# Altium schematic sheet Sheet1.SchDoc
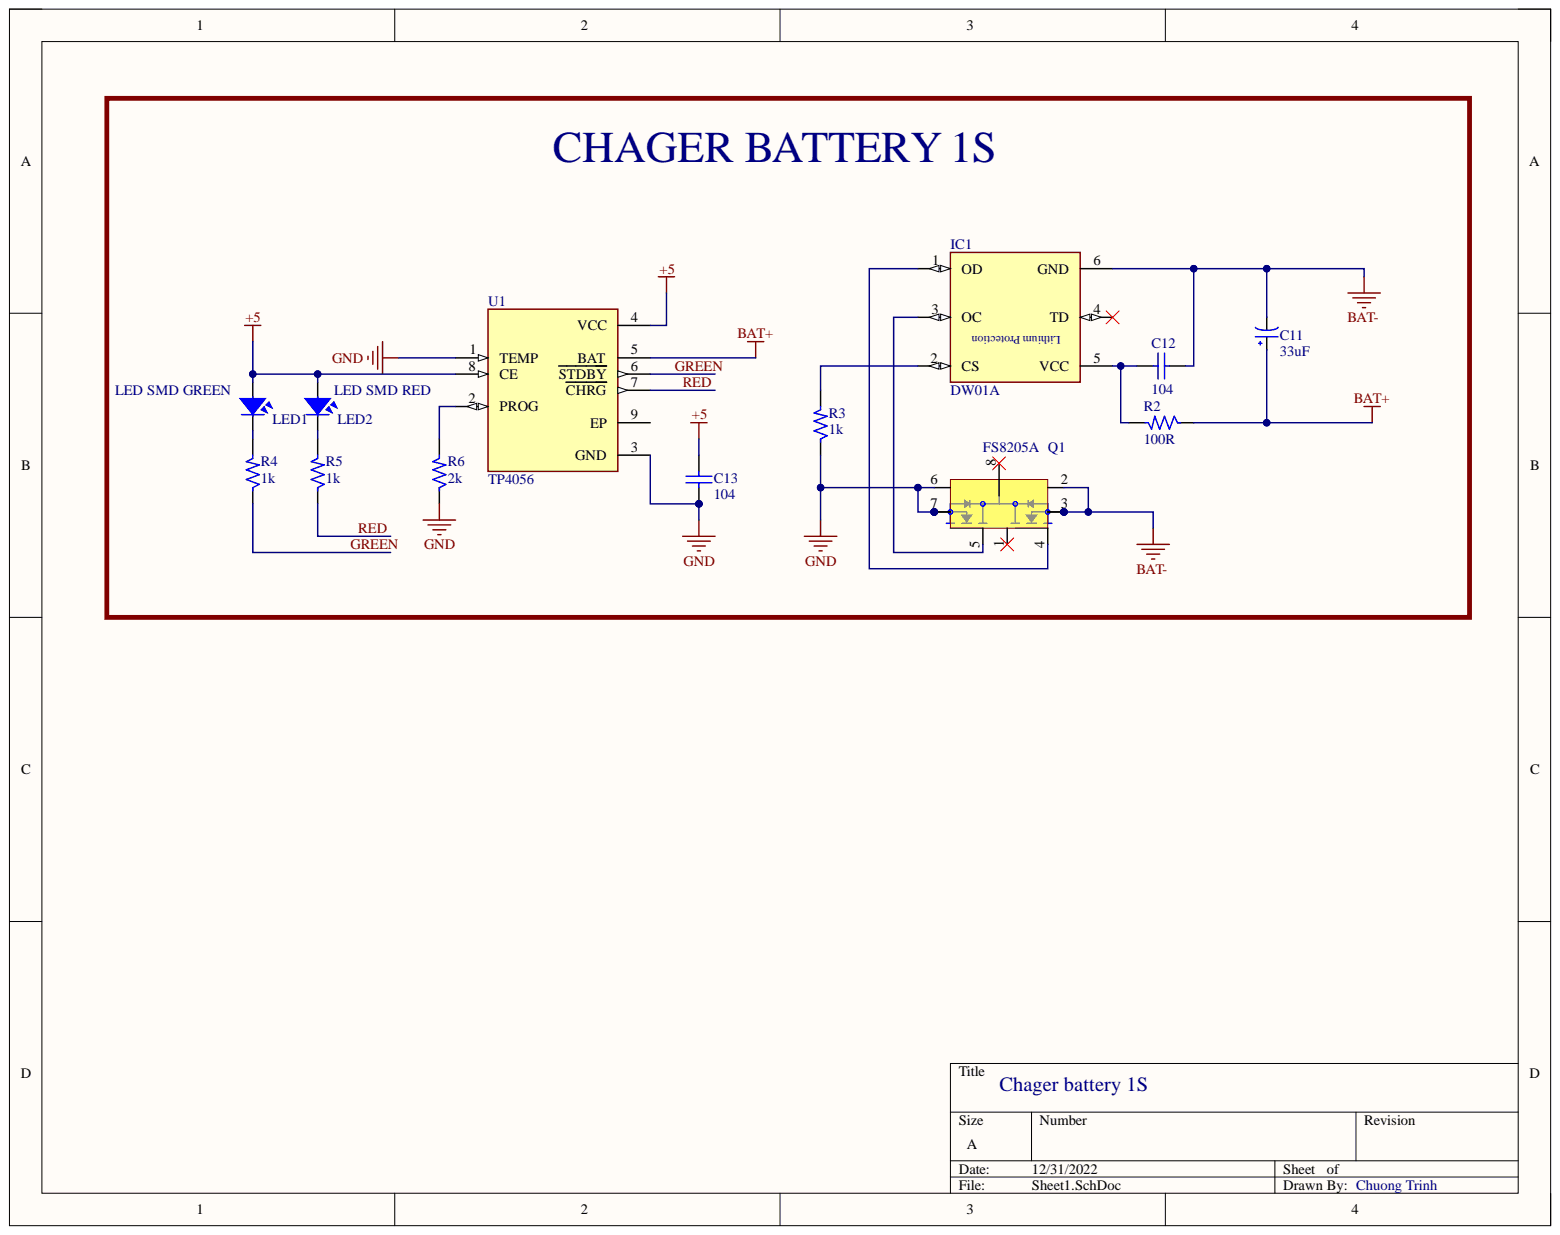
[diagram p0: Sheet1.SchDoc]

## Parts
C11  33uF  [tantalum smd3216]
C12  104  [Cap Ceramic 0805]
C13  104  [Cap Ceramic 0805]
IC1  DW01A  [DW01A]
LED1  LED SMD GREEN  [LED-0805-Green]
LED2  LED SMD RED  [LED-0805-Red]
Q1  FS8205A  [FS8205A]
R2  100R  [Res_0805]
R3  1k  [Res_0805]
R4  1k  [Res_0805]
R5  1k  [Res_0805]
R6  2k  [Res_0805]
U1  TP4056  [TP4056]

## Nets
+5: C13.2 LED1.1 LED2.1 U1.4(VCC) U1.8(CE)
BAT+: C11.1 R2.1 U1.5(BAT)
BAT-: C11.2 C12.2 IC1.6(GND) Q1.2 Q1.3
GND: C13.1 Q1.6 Q1.7 R3.1 R6.1 U1.1(TEMP) U1.3(GND)
GREEN: R4.1 U1.6(STDBY)
RED: R5.1 U1.7(CHRG)
NetC12_1: C12.1 IC1.5(VCC) R2.2
NetIC1_1: IC1.1(OD) Q1.4
NetIC1_2: IC1.2(CS) R3.2
NetIC1_3: IC1.3(OC) Q1.5
NetLED1_2: LED1.2 R4.2
NetLED2_2: LED2.2 R5.2
NetR6_2: R6.2 U1.2(PROG)

Not connected: IC1 4(TD); Q1 1 8; U1 9(EP)

## Library data of the parts
C11 = Tantalum SMD3216: SMD; Chung_Loai: Dán; Don_Vi: Con; Ma_Hang: MH008674; So_Chan: 2; Ten_Linh_Kien: Tụ tantalum J336-33uF 6.3V SMD3216; Component Kind: Standard; Library Name: KS Chuong.SchLib
C12 C13 = CAP Ceramic SMD: Component Kind: Standard; Description: Cap Ceramic SMD; Library Name: KS Chuong.SchLib
IC1 = DW01A: Pushbutton Switch SPST-NC Standard Panel Mount, Front; MF: Fortune Semiconductor; Availability: Unavailable; Component Kind: Standard; MP: DW01A; Package: Package Analog Devices; Price: None; SnapEDA_Link: https://www.snapeda.com/parts/DW01A/Fortune%20Semiconductor/view-part/4388554/?ref=snap; Library Name: KS Chuong.SchLib
LED1 LED2 = LED-SMD-0805: Component Kind: Standard; Description: LED-SMD-0805; Library Name: KS Chuong.SchLib
Q1 = FS8205A: N/A; Availability: Unavailable; Component Kind: Standard; MP: FS8205A; Package: None; Price: None; Library Name: KS Chuong.SchLib
R2-R6 = Res SMD: Component Kind: Standard; Library Name: KS Chuong.SchLib
U1 = TP4056: Complete single cell Li-Ion battery with a constant current / constant voltage linear charger; Availability: Unavailable; Component Kind: Standard; MP: TP4056; Package: None; Price: None; Library Name: KS Chuong.SchLib
